# Altium schematic sheet Source_Pi.SchDoc(Source_Pi)
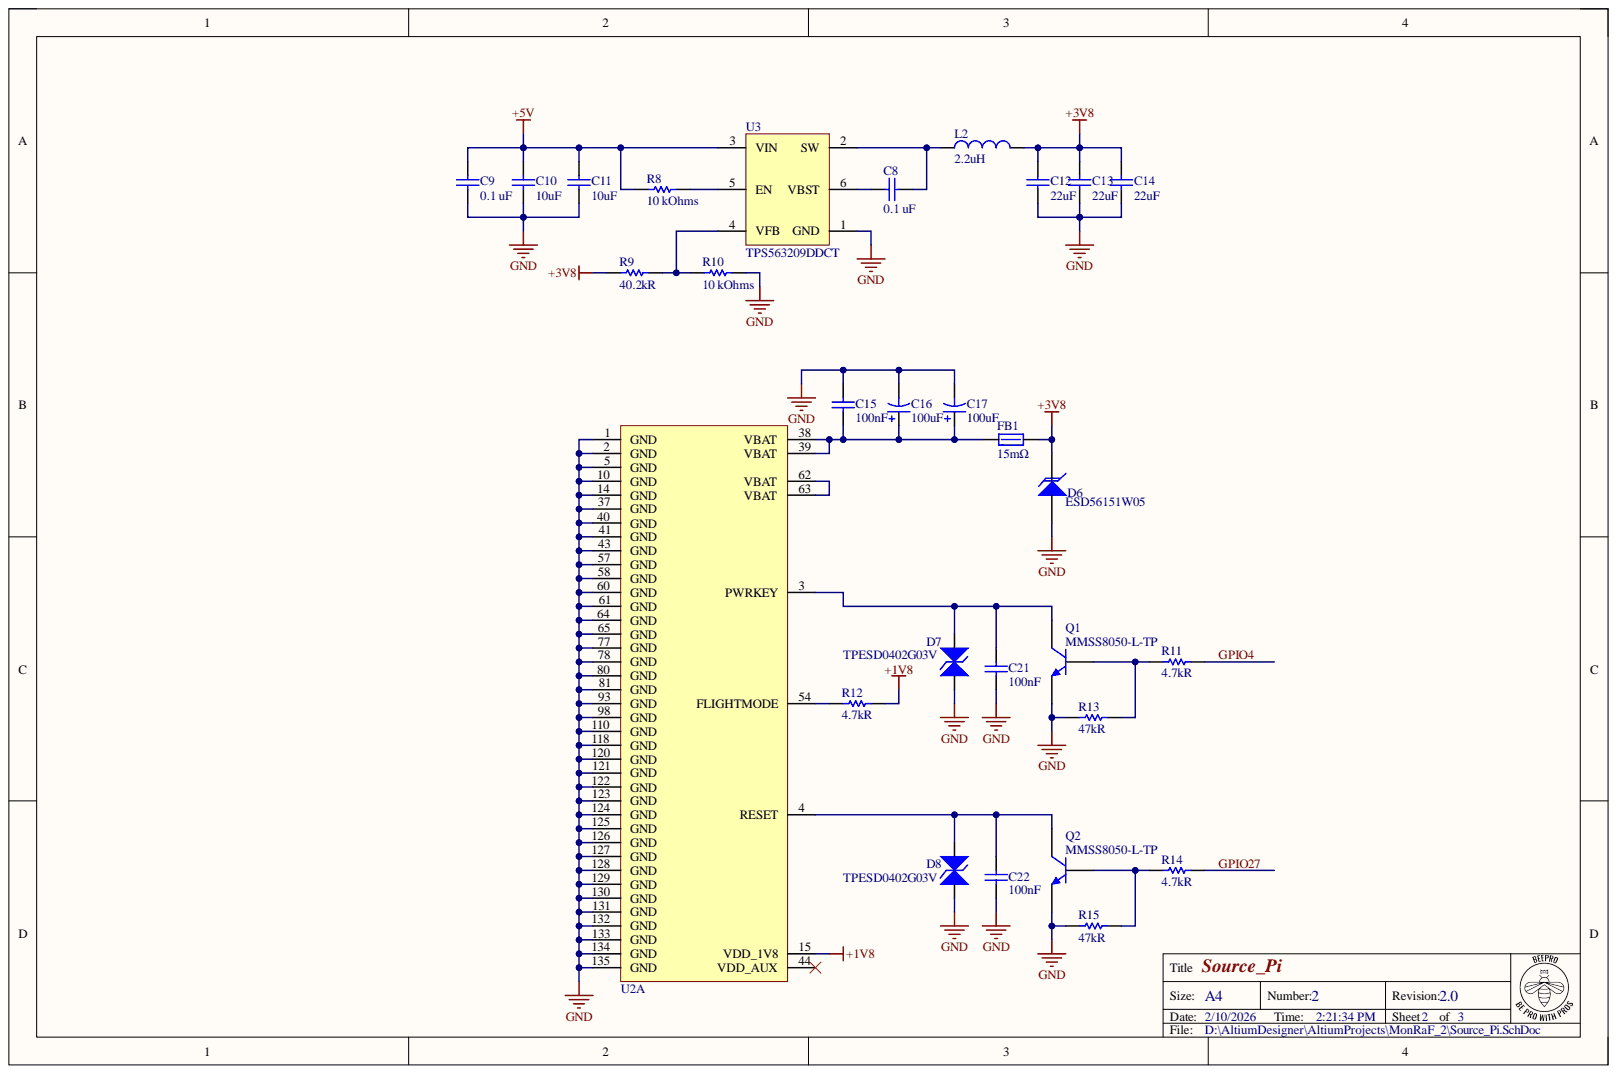
[diagram p0: Source_Pi.SchDoc]

## Parts
C8  Capacitance: 0.1 uF  [0603N]  (hidden comment: 0603YC104KAT2A)
C9  0603YC104KAT2A  [0603N]  (value not drawn)
C10  Capacitance: 10uF  [0603N]  (hidden comment: C1608X5R1E106M080AC)
C11  Capacitance: 10uF  [0603N]  (hidden comment: C1608X5R1E106M080AC)
C12  Capacitance: 22uF  [0603N]  (hidden comment: C1608JB1A226M080AC)
C13  Capacitance: 22uF  [0603N]  (hidden comment: C1608JB1A226M080AC)
C14  Capacitance: 22uF  [0603N]  (hidden comment: C1608JB1A226M080AC)
C15  Capacitance: 100nF  [0402N]  (hidden comment: 04026D104KAT2A)
C16  value 100uF  [Case-A]  (hidden comment: TMCMA0J107MTRF)
C17  value 100uF  [Case-A]  (hidden comment: TMCMA0J107MTRF)
C21  Capacitance: 100nF  [0402N]  (hidden comment: 04026D104KAT2A)
C22  Capacitance: 100nF  [0402N]  (hidden comment: 04026D104KAT2A)
D6  ESD56151W05  [SOD-323]
D7  TPESD0402G03V  [DFN0402-2N]
D8  TPESD0402G03V  [DFN0402-2N]
FB1  value 15mΩ  [0805]  (hidden comment: BLM21PG300SN1D)
L2  Inductance-Nom (L): 2.2uH  [SRN6045]  (hidden comment: SRN6045TA-2R2Y)
Q1  MMSS8050-L-TP  [SOT23-3N]
Q2  MMSS8050-L-TP  [SOT23-3N]
R8  Resistance: 10 kOhms  [0603N]  (hidden comment: CRCW060310K0FKEA)
R9  value 40.2kR  [0603N]  (hidden comment: C0603FR-0740K2L)
R10  Resistance: 10 kOhms  [0603N]  (hidden comment: CRCW060310K0FKEA)
R11  Resistance: 4.7kR  [0402N]  (hidden comment: RC0402FR-074K7L)
R12  Resistance: 4.7kR  [0402N]  (hidden comment: RC0402FR-074K7L)
R13  Resistance: 47kR  [0402N]  (hidden comment: AC0402FR-0747KL)
R14  Resistance: 4.7kR  [0402N]  (hidden comment: RC0402FR-074K7L)
R15  Resistance: 47kR  [0402N]  (hidden comment: AC0402FR-0747KL)
U2  SIM7600G-H  [LCC+LGA-119N]  (value not drawn)  (part A)
U3  TPS563209  Manufacturer Part Number: TPS563209DDCT  [SOT23-6N]

## Nets
+1V8: R12.2 U2.15(VDD_1V8)
+3V8: C12.2 C13.2 C14.2 D6.1 FB1.2 L2.2 R9.1
+5V: C9.2 C10.2 C11.2 R8.2 U3.3(VIN)
GND: C9.1 C10.1 C11.1 C12.1 C13.1 C14.1 C15.1 C16.2 C17.2 C21.2 C22.2 D6.2 D7.1 D8.1 Q1.2 Q2.2 R10.1 R13.1 R15.1 U2.1(GND) U2.2(GND) U2.5(GND) U2.10(GND) U2.14(GND) U2.37(GND) U2.40(GND) U2.41(GND) U2.43(GND) U2.57(GND) U2.58(GND) U2.60(GND) U2.61(GND) U2.64(GND) U2.65(GND) U2.77(GND) U2.78(GND) U2.80(GND) U2.81(GND) U2.93(GND) U2.98(GND) U2.110(GND) U2.118(GND) U2.120(GND) U2.121(GND) U2.122(GND) U2.123(GND) U2.124(GND) U2.125(GND) U2.126(GND) U2.127(GND) U2.128(GND) U2.129(GND) U2.130(GND) U2.131(GND) U2.132(GND) U2.133(GND) U2.134(GND) U2.135(GND) U3.1(GND)
GPIO4: R11.2
GPIO27: R14.2
NetC8_1: C8.1 L2.1 U3.2(SW)
NetC8_2: C8.2 U3.6(VBST)
NetC15_2: C15.2 C16.1 C17.1 FB1.1 U2.38(VBAT) U2.39(VBAT)
NetC21_1: C21.1 D7.2 Q1.3 U2.3(PWRKEY)
NetC22_1: C22.1 D8.2 Q2.3 U2.4(RESET)
NetQ1_1: Q1.1 R11.1 R13.2
NetQ2_1: Q2.1 R14.1 R15.2
NetR8_1: R8.1 U3.5(EN)
NetR9_2: R9.2 R10.2 U3.4(VFB)
NetR12_1: R12.1 U2.54(FLIGHTMODE)
NetU2_62: U2.62(VBAT) U2.63(VBAT)

Not connected: U2 44(VDD_AUX)

## Library data of the parts
C8 = 0603YC104KAT2A: Multilayer Ceramic Capacitors MLCC - SMD/SMT 16V .1uF X7R 0603 10% Tol; Brand: AVX; Capacitance - nF: 100 nF; Capacitance - pF: 100000 pF; Class: Class 2; Type: X7R MLC Capacitors; Voltage Rating DC: 16 VDC; Case Code - in: 0603; Case Code - mm: 1608; Category: Multilayer Ceramic Capacitors MLCC - SMD/SMT; Component Kind: Standard; ComponentLink1Description: http://www.mouser.com/ds/2/40/X7RDielectric-777024.pdf; ComponentLink1URL: http://www.mouser.com/ds/2/40/X7RDielectric-777024.pdf; Dielectric: X7R; Height: 0.35 mm; Length: 1.6 mm; Library Name: Capacitor_Ceramic.IntLib; Manufacturer: AVX; Manufacturer Part Number 1: 0603YC104KAT2A; Maximum Operating Temperature: + 125 C; Minimum Operating Temperature: - 55 C; Minimum Order Quantity: 1; Package / Case: 0603 (1608 metric); Packaging: Reel; Pricing 1: 1=0.100, 50=0.011, 100=0.007, 500=0.006, 1000=0.005, 4000=0.003 (USD); Product: General Type MLCCs; Reeling: True; RoHS: RoHS Compliant By Exemption; RoHS - Mouser: E; Standard Pack Qty: 4000; Stock 1: 207167; Supplier 1: Mouser; Supplier Part Number 1: 581-060316C104K; Termination: -; Termination Style: SMD/SMT; Tolerance: 10 %; Width: 0.8 mm
C9 = 0603YC104KAT2A: Multilayer Ceramic Capacitors MLCC - SMD/SMT 16V .1uF X7R 0603 10% Tol; Brand: AVX; Capacitance: 0.1 uF; Capacitance - nF: 100 nF; Capacitance - pF: 100000 pF; Class: Class 2; Type: X7R MLC Capacitors; Voltage Rating DC: 16 VDC; Case Code - in: 0603; Case Code - mm: 1608; Category: Multilayer Ceramic Capacitors MLCC - SMD/SMT; Component Kind: Standard; ComponentLink1Description: http://www.mouser.com/ds/2/40/X7RDielectric-777024.pdf; ComponentLink1URL: http://www.mouser.com/ds/2/40/X7RDielectric-777024.pdf; Dielectric: X7R; Height: 0.35 mm; Length: 1.6 mm; Library Name: Capacitor_Ceramic.IntLib; Manufacturer: AVX; Manufacturer Part Number 1: 0603YC104KAT2A; Maximum Operating Temperature: + 125 C; Minimum Operating Temperature: - 55 C; Minimum Order Quantity: 1; Package / Case: 0603 (1608 metric); Packaging: Reel; Pricing 1: 1=0.100, 50=0.011, 100=0.007, 500=0.006, 1000=0.005, 4000=0.003 (USD); Product: General Type MLCCs; Reeling: True; RoHS: RoHS Compliant By Exemption; RoHS - Mouser: E; Standard Pack Qty: 4000; Stock 1: 207167; Supplier 1: Mouser; Supplier Part Number 1: 581-060316C104K; Termination: -; Termination Style: SMD/SMT; Tolerance: 10 %; Width: 0.8 mm
C10 C11 = C1608X5R1E106M080AC: CAP CER 10UF 25V X5R 0603; Manufacturer: TDK; Dielectric Material: Ceramic; Tolerance: 20%; Case Code (Imperial): 0603; Case Code (Metric): 1608; Case/Package: 0603; Component Kind: Standard; ComponentLink1Description: https://product.tdk.com/info/en/catalog/datasheets/mlcc_commercial_general_en.pdf; ComponentLink1URL: https://product.tdk.com/info/en/catalog/datasheets/mlcc_commercial_general_en.pdf; ComponentLink2Description: http://www.farnell.com/datasheets/2291921.pdf; ComponentLink2URL: http://www.farnell.com/datasheets/2291921.pdf; ComponentLink3Description: http://www.farnell.com/datasheets/1913358.pdf; ComponentLink3URL: http://www.farnell.com/datasheets/1913358.pdf; ComponentLink4Description: http://components.arrow.com/part/dataview/57436044S459551N1165; ComponentLink4URL: http://components.arrow.com/part/dataview/57436044S459551N1165; ComponentLink5Description: http://components.arrow.com/part/dataview/0323_06887183; ComponentLink5URL: http://components.arrow.com/part/dataview/0323_06887183; Composition: Ceramic; Depth: 0.8mm; Dielectric: X5R; Height: 0.8mm; Lead Free: Lead Free; Length: 1.6mm; Library Name: Capacitor_Ceramic.IntLib; Manufacturer Part Number: C1608X5R1E106M080AC; Max Operating Temperature: 85°C; Min Operating Temperature: -55°C; Mount: Surface Mount; Package Quantity: 1; Packaging: Tape and Reel; Pricing 1: 1=0.88, 10=0.742, 50=0.742, 100=0.364, 1000=0.301, 10000=0.28 (USD); REACH SVHC: No SVHC; Stock 1: 225396; Supplier 1: Mouser; Supplier Part Number 1: 810-C1608X5R1E106M; Termination: SMD/SMT; Thickness: 0.039inch; Voltage Rating: 25V; Voltage Rating (DC): 25V; Weight: 7.1E-05oz; Width: 0.8mm
C12-C14 = C1608JB1A226M080AC: CAP CER 22UF 10V JB 0603; Manufacturer: TDK; Dielectric Material: Ceramic; Tolerance: 20%; Case Code (Imperial): 0603; Case Code (Metric): 1608; Case/Package: 0603; Category: Ceramic Capacitors; Component Kind: Standard; ComponentLink1Description: https://datasheet.ciiva.com/14905/tdkmlcccaprange-14905338.pdf?src-supplier=Digi-Key; ComponentLink1URL: https://datasheet.ciiva.com/14905/tdkmlcccaprange-14905338.pdf?src-supplier=Digi-Key; ComponentLink2Description: https://datasheet.ciiva.com/14905/tdkmlcccaprange-14905341.pdf?src-supplier=Digi-Key; ComponentLink2URL: https://datasheet.ciiva.com/14905/tdkmlcccaprange-14905341.pdf?src-supplier=Digi-Key; ComponentLink3Description: https://datasheet.ciiva.com/14905/tdkmlcccaprange-14905339.pdf?src-supplier=Digi-Key; ComponentLink3URL: https://datasheet.ciiva.com/14905/tdkmlcccaprange-14905339.pdf?src-supplier=Digi-Key; Lead Free: Lead Free; Length: 0.063inch; Library Name: Capacitor_Ceramic.IntLib; Manufacturer Part Number: C1608JB1A226M080AC; Max Operating Temperature: 85°C; Min Operating Temperature: -25°C; Mount: Surface Mount; Package Quantity: 4000; Packaging: Tape and Reel; Pricing 1: 1=0.45000, 10=0.23900, 50=0.23900, 100=0.19500, 1000=0.14700, 10000=0.14000 (USD); RoHS: Yes; RoHS Compliant: Yes; Series: C; Stock 1: 20532; Supplier 1: Mouser; Supplier Part Number 1: 810-C1608JB1A226M8AC; Thickness: 0.035inch; Voltage Rating: 10V; Voltage Rating (DC): 10V; Width: 0.031inch
C15 C21 C22 = 04026D104KAT2A: AVX   04026D104KAT2A   Multilayer Ceramic Capacitor, 0.1 F,  10%, X5R, 6.3 V, 0402 [1005 Metric]; Manufacturer: Kyocera AVX; Voltage: 6.3V; Case Code (Imperial): 0402; Case Code (Metric): 1005; Case/Package: 0402; Component Kind: Standard; ComponentLink1Description: https://datasheet.ciiva.com/11787/0900766b80def1a5-11787108.pdf?src-supplier=RSComponents; ComponentLink1URL: https://datasheet.ciiva.com/11787/0900766b80def1a5-11787108.pdf?src-supplier=RSComponents; ComponentLink2Description: https://datasheet.ciiva.com/34303/2009885-34303800.pdf?src-supplier=Jameco; ComponentLink2URL: https://datasheet.ciiva.com/34303/2009885-34303800.pdf?src-supplier=Jameco; ComponentLink3Description: https://datasheet.ciiva.com/1123/1666675-1123895.pdf?src-supplier=Element14; ComponentLink3URL: https://datasheet.ciiva.com/1123/1666675-1123895.pdf?src-supplier=Element14; ComponentLink4Description: https://datasheet.ciiva.com/7709/1666675-7709559.pdf?src-supplier=Element14; ComponentLink4URL: https://datasheet.ciiva.com/7709/1666675-7709559.pdf?src-supplier=Element14; ComponentLink5Description: https://datasheet.ciiva.com/17488/cx5r-17488599.pdf?src-supplier=Avnet+Europe; ComponentLink5URL: https://datasheet.ciiva.com/17488/cx5r-17488599.pdf?src-supplier=Avnet+Europe; ComponentLink6Description: https://datasheet.ciiva.com/1123/1666675-1123896.pdf?src-supplier=Element14; ComponentLink6URL: https://datasheet.ciiva.com/1123/1666675-1123896.pdf?src-supplier=Element14; ComponentLink7Description: https://datasheet.ciiva.com/11499/cx5r-11499578.pdf?src-supplier=Avnet; ComponentLink7URL: https://datasheet.ciiva.com/11499/cx5r-11499578.pdf?src-supplier=Avnet; ComponentLink8Description: https://datasheet.ciiva.com/210/cx5r-210347.pdf?src-supplier=Digi-Key; ComponentLink8URL: https://datasheet.ciiva.com/210/cx5r-210347.pdf?src-supplier=Digi-Key; ComponentLink9Description: https://datasheet.ciiva.com/14886/cx5r-14886812.pdf?src-supplier=Digi-Key; ComponentLink9URL: https://datasheet.ciiva.com/14886/cx5r-14886812.pdf?src-supplier=Digi-Key; ComponentLink10Description: https://datasheet.ciiva.com/2987/cx5r-2987693.pdf?src-supplier=Avnet; ComponentLink10URL: https://datasheet.ciiva.com/2987/cx5r-2987693.pdf?src-supplier=Avnet; Contact Plating: Tin; Depth: 0.5mm; Dielectric: X5R; Dielectric Material: Ceramic; Height: 0.56mm; Lead Free: Lead Free; Length: 1mm; Library Name: Capacitor_Ceramic.IntLib; Manufacturer Part Number: 04026D104KAT2A; Max Operating Temperature: 85°C; Min Operating Temperature: -55°C; Mount: Surface Mount; Package Quantity: 1; Packaging: Tape and Reel; Pricing 1: 1 = 0.10000, 10 = 0.04100, 50 = 0.04100, 100 = 0.01900, 1000 = 0.01000, 10000 = 0.00700 (USD); Radiation Hardening: No; REACH SVHC: No SVHC; RoHS: Yes; Stock 1: 118561; Supplier 1: Mouser; Supplier Part Number 1: 581-04026D104KAT2A; Termination: SMD/SMT; Thickness: 0.022inch; Tolerance: 10%; Voltage Rating: 6.3V; Voltage Rating (DC): 6.3V; Width: 0.02inch
C16 C17 = TMCMA0J107MTRF: Tantalum Capacitor, Polarized, Tantalum (dry/solid), 6.3V, 20% +Tol, 20% -Tol, 100uF, Surface Mount, 1306; Manufacturer: Vishay Sprague; ESR (Equivalent Series Resistance): 1.8R; Capacitor Type: Tantalum; Case/Package: 1206; Category: Tantalum Capacitors; Component Kind: Standard; ComponentLink1Description: https://datasheet.ciiva.com:443/pdfs/VipMasterIC/IC/VISH/VISH-S-A0003607254/VISH-S-A0003607254-1.pdf?src-supplier=IHS+Markit; ComponentLink1URL: https://datasheet.ciiva.com:443/pdfs/VipMasterIC/IC/VISH/VISH-S-A0003607254/VISH-S-A0003607254-1.pdf?src-supplier=IHS+Markit; ComponentLink2Description: http://www.farnell.com/datasheets/2245108.pdf?src-supplier=Farnell; ComponentLink2URL: http://www.farnell.com/datasheets/2245108.pdf?src-supplier=Farnell; ComponentLink3Description: http://www.mouser.com/ds/2/427/tmcm-515668.pdf?src-supplier=Mouser; ComponentLink3URL: http://www.mouser.com/ds/2/427/tmcm-515668.pdf?src-supplier=Mouser; ComponentLink4Description: http://datasheet.octopart.com/TMCMA0J107MTRF-Vishay-datasheet-46753192.pdf?src-supplier=Farnell; ComponentLink4URL: http://datasheet.octopart.com/TMCMA0J107MTRF-Vishay-datasheet-46753192.pdf?src-supplier=Farnell; ComponentLink5Description: http://datasheet.octopart.com/TMCMA0J107MTRF-Vishay-datasheet-46753192.pdf?src-supplier=Newark; ComponentLink5URL: http://datasheet.octopart.com/TMCMA0J107MTRF-Vishay-datasheet-46753192.pdf?src-supplier=Newark; ComponentLink6Description: https://datasheet.octopart.com/TMCMA0J107MTRF-Vishay-datasheet-78835373.pdf?src-supplier=Element14; ComponentLink6URL: https://datasheet.octopart.com/TMCMA0J107MTRF-Vishay-datasheet-78835373.pdf?src-supplier=Element14; ComponentLink7Description: http://datasheet.octopart.com/TMCMA0J107MTRF-Vishay-datasheet-46753192.pdf?src-supplier=Distrelec; ComponentLink7URL: http://datasheet.octopart.com/TMCMA0J107MTRF-Vishay-datasheet-46753192.pdf?src-supplier=Distrelec; ComponentLink8Description: http://www.vishay.com/docs/40178/tmcm.pdf?src-supplier=Avnet; ComponentLink8URL: http://www.vishay.com/docs/40178/tmcm.pdf?src-supplier=Avnet; ComponentLink9Description: http://datasheet.octopart.com/TMCMA0J107MTRF-Vishay-datasheet-46753192.pdf?src-supplier=Digikey; ComponentLink9URL: http://datasheet.octopart.com/TMCMA0J107MTRF-Vishay-datasheet-46753192.pdf?src-supplier=Digikey; ComponentLink10Description: http://datasheet.octopart.com/TMCMA0J107MTRF-Vishay-datasheet-46753192.pdf?src-supplier=Future+Electronics; ComponentLink10URL: http://datasheet.octopart.com/TMCMA0J107MTRF-Vishay-datasheet-46753192.pdf?src-supplier=Future+Electronics; ComponentLink11Description: http://datasheet.octopart.com/TMCMA0J107MTRF-Vishay-datasheet-46753192.pdf?src-supplier=TTI; ComponentLink11URL: http://datasheet.octopart.com/TMCMA0J107MTRF-Vishay-datasheet-46753192.pdf?src-supplier=TTI; Dielectric Material: Tantalum; Height: 1.6mm; JESD-609 Code: e3; Length: 3.2mm; Library Name: Tantalum_Capacitor.IntLib; Manufacturer Part Number: TMCMA0J107MTRF; Max Operating Temperature: 125°C; Min Operating Temperature: -55°C; Package Style: SMTMeter; Packaging: Tape and Reel; Pins: 2; Polarity: Polarized; Pricing 1: 5=0.1537, 50=0.1245, 150=0.1103, 500=0.0976, 2000=0.0789, 4000=0.0768 (USD); Rated DC Voltage (URdc): 6.3V; Reach SVHC Compliant: Yes; RoHS: Yes; RoHS Compliant: Yes; Stock 1: 20981; Supplier 1: LCSC; Supplier Part Number 1: C1954161; Terminal Finish: Tin; Tolerance  (fill in as +-): 20%; Tolerance (fill in as +-): 20%; Width: 1.6mm
D6 = ESD56151W05-2/TR: 145A@8/20us 7.5V 2.4kW@8/20us 6.3V Bidirectional 5V SOD-323 TVS Diodes ROHS; Component Kind: Standard; Library Name: Diodes.IntLib
D7 D8 = TPESD0402G03V: 0402 ESD and Surge Protection (TVS/ESD) ROHS; Manufacturer: Tech Public; Category: Uncategorized; Component Kind: Standard; Library Name: Diodes.IntLib; Manufacturer Part Number: TPESD0402G03V; Pricing: 20=0.158, 20=0.1448, 20=0.0198, 20=0.0173, 200=0.1258, 200=0.0172, 200=0.1373, 200=0.015, 600=0.1261, 600=0.1155, 600=0.0138, 600=0.0158, 2000=0.1097, 2000=0.0131, 2000=0.015, 2000=0.1197, 10000=0.1014, 10000=0.0111, 10000=0.0929, 10000=0.0127, 20000=0.0982, 20000=0.09, 20000=0.0107, 20000=0.0123 (HKD); Stock: 11840; Supplier: LCSC; Supplier Part Number: C558614
FB1 = BLM21PG300SN1D: Ferrite Beads Multi-Layer 30Ohm 100MHz 4A 14mOhm DCR 0805 Paper T/R; Manufacturer: Murata; Current Rating: 2.3A; DC Current: 3A; DC Resistance (DCR): 14mΩ; Impedance: 30Ω; Material: Ferrite, Steel; Number of Circuits: 1; Shielding: Unshielded; Tolerance: 25%; Case Code (Imperial): 0805; Case Code (Metric): 2012; Case/Package: 0805; Category: Ferrite Beads and Chips; Clock Frequency: 100MHz; Component Kind: Standard; ComponentLink1Description: https://datasheet.octopart.com:443/BLM21PG300SN1D-Murata-datasheet-12522583.pdf?src-supplier=Upverter; ComponentLink1URL: https://datasheet.octopart.com:443/BLM21PG300SN1D-Murata-datasheet-12522583.pdf?src-supplier=Upverter; ComponentLink2Description: https://datasheet.octopart.com:443/BLM21PG300SN1D-Murata-datasheet-10083551.pdf?src-supplier=Upverter; ComponentLink2URL: https://datasheet.octopart.com:443/BLM21PG300SN1D-Murata-datasheet-10083551.pdf?src-supplier=Upverter; ComponentLink3Description: https://datasheet.octopart.com:443/BLM21PG300SN1D-Murata-datasheet-8508678.pdf?src-supplier=Upverter; ComponentLink3URL: https://datasheet.octopart.com:443/BLM21PG300SN1D-Murata-datasheet-8508678.pdf?src-supplier=Upverter; ComponentLink4Description: https://datasheet.octopart.com:443/BLM21PG300SN1D-Murata-datasheet-166171482.pdf?src-supplier=IHS; ComponentLink4URL: https://datasheet.octopart.com:443/BLM21PG300SN1D-Murata-datasheet-166171482.pdf?src-supplier=IHS; ComponentLink5Description: https://datasheet.octopart.com:443/BLM21PG300SN1D-Murata-datasheet-11743916.pdf?src-supplier=Newark; ComponentLink5URL: https://datasheet.octopart.com:443/BLM21PG300SN1D-Murata-datasheet-11743916.pdf?src-supplier=Newark; ComponentLink6Description: https://datasheet.octopart.com:443/BLM21PG300SN1D-Murata-datasheet-53019.pdf?src-supplier=Newark; ComponentLink6URL: https://datasheet.octopart.com:443/BLM21PG300SN1D-Murata-datasheet-53019.pdf?src-supplier=Newark; ComponentLink7Description: https://datasheet.octopart.com:443/BLM21PG300SN1D-Murata-datasheet-8359900.pdf?src-supplier=_legacy+Avnet; ComponentLink7URL: https://datasheet.octopart.com:443/BLM21PG300SN1D-Murata-datasheet-8359900.pdf?src-supplier=_legacy+Avnet; ComponentLink8Description: https://datasheet.octopart.com:443/BLM21PG300SN1D-Murata-datasheet-554271.pdf?src-supplier=_legacy+Avnet; ComponentLink8URL: https://datasheet.octopart.com:443/BLM21PG300SN1D-Murata-datasheet-554271.pdf?src-supplier=_legacy+Avnet; ComponentLink9Description: https://datasheet.octopart.com:443/BLM21PG300SN1D-Murata-datasheet-141258122.pdf?src-supplier=Ciiva; ComponentLink9URL: https://datasheet.octopart.com:443/BLM21PG300SN1D-Murata-datasheet-141258122.pdf?src-supplier=Ciiva; ComponentLink10Description: https://datasheet.octopart.com:443/BLM21PG300SN1D-Murata-datasheet-138707422.pdf?src-supplier=Ciiva; ComponentLink10URL: https://datasheet.octopart.com:443/BLM21PG300SN1D-Murata-datasheet-138707422.pdf?src-supplier=Ciiva; ComponentLink11Description: https://datasheet.octopart.com:443/BLM21PG300SN1D-Murata-datasheet-135086728.pdf?src-supplier=TME; ComponentLink11URL: https://datasheet.octopart.com:443/BLM21PG300SN1D-Murata-datasheet-135086728.pdf?src-supplier=TME; ComponentLink12Description: https://datasheet.octopart.com:443/BLM21PG300SN1D-Murata-datasheet-62086383.pdf?src-supplier=Future+Electronics; ComponentLink12URL: https://datasheet.octopart.com:443/BLM21PG300SN1D-Murata-datasheet-62086383.pdf?src-supplier=Future+Electronics; ComponentLink13Description: https://datasheet.octopart.com/BLM21PG300SN1D-Murata-datasheet-37129980.pdf; ComponentLink13URL: https://datasheet.octopart.com/BLM21PG300SN1D-Murata-datasheet-37129980.pdf; ComponentLink14Description: https://datasheet.octopart.com:443/BLM21PG300SN1D-Murata-datasheet-7546143.pdf?src-supplier=Jameco; ComponentLink14URL: https://datasheet.octopart.com:443/BLM21PG300SN1D-Murata-datasheet-7546143.pdf?src-supplier=Jameco; ComponentLink15Description: https://datasheet.octopart.com:443/BLM21PG300SN1D-Murata-datasheet-153769.pdf?src-supplier=Mouser; ComponentLink15URL: https://datasheet.octopart.com:443/BLM21PG300SN1D-Murata-datasheet-153769.pdf?src-supplier=Mouser; ComponentLink16Description: https://datasheet.octopart.com:443/BLM21PG300SN1D-Murata-datasheet-1584.pdf?src-supplier=RS+(Formerly+Allied+Electronics); ComponentLink16URL: https://datasheet.octopart.com:443/BLM21PG300SN1D-Murata-datasheet-1584.pdf?src-supplier=RS+(Formerly+Allied+Electronics); ComponentLink17Description: https://datasheet.octopart.com:443/BLM21PG300SN1D-Murata-datasheet-151955976.pdf?src-supplier=SOS+electronic; ComponentLink17URL: https://datasheet.octopart.com:443/BLM21PG300SN1D-Murata-datasheet-151955976.pdf?src-supplier=SOS+electronic; ComponentLink18Description: http://sigma.octopart.com:80/reach_statement/Murata-company-16.pdf?src-supplier=Compliance+Statements; ComponentLink18URL: http://sigma.octopart.com:80/reach_statement/Murata-company-16.pdf?src-supplier=Compliance+Statements; Core Material: Ferrite; Depth: 1.25mm; ESR: 14mΩ; Frequency: 100MHz; Height: 850µm; Lead Free: Lead Free; Length: 2mm; Library Name: Inductors.IntLib; Lifecycle Status: Production; Manufacturer Part Number: BLM21PG300SN1D; Max Current Rating: 4A; Max DC Current: 3A; Max Operating Temperature: 125°C; Military Standard: Not; Min Operating Temperature: -55°C; Number of Lines: 1; Number of Terminals: 2; Packaging: Tape and Reel; Pins: 2; Pricing: 50=0.0142, 50=0.0163, 50=0.1301, 50=0.1192, 500=0.013, 500=0.1038, 500=0.0114, 500=0.0951, 1500=0.0097, 1500=0.0111, 1500=0.0812, 1500=0.0886, 4000=0.010, 4000=0.0731, 4000=0.0798, 4000=0.0087, 24000=0.0079, 24000=0.0658, 24000=0.009, 24000=0.0719, 48000=0.0074, 48000=0.0085, 48000=0.0622, 48000=0.0679 (EUR); Radiation Hardening: No; REACH SVHC: Yes; RMS Current (Irms): 4A; RoHS: Compliant; Schedule B: 8504500000, 8504500000|8504500000|8504500000|8504500000|8504500000|8504500000|8504500000|8504500000|8504500000|8504500000|8504500000|8504500000|8504500000|8504500000|8504500000|8504500000, 8504500000|8504500000|8504500000|8504500000|8504500000|8504500000|8504500000|8504500000|8504500000|8504500000|8504500000|8504500000|8504500000|8504500000|8504500000|8504500000|8504500000, 8504500000|8504500000|8504500000|8504500000|8504500000|8504500000|8504500000|8504500000|8504500000|8504500000|8504500000|8504500000|8504500000|8504500000|8504500000|8504500000|8504500000|8504500000; Stock: 146550; Supplier: LCSC; Supplier Part Number: C16903; Termination: SMD/SMT; Test Frequency: 100MHz; Width: 1.25mm
L2 = SRN6045TA-2R2Y: General Purpose Inductor, 2.2uH, 30%, 1 Element, Ferrite-Core, SMD, 2424; Manufacturer: Bourns; Channels: 1; DC Resistance (DCR): 15mR; Inductor Application: POWER INDUCTOR; Max Current Rating: 6A; Quality Factor-Min (at L-nom): 15; Reference Standard: AEC-Q200; Self Resonant Frequency: 55MHz; Shape/Size Description: RECTANGULAR PACKAGE; Shielding: Shielded; Test Frequency: 1MHz; Category: Fixed Inductors; Component Kind: Standard; ComponentLink1Description: https://datasheet.ciiva.com:443/pdfs/VipMasterIC/IC/BOUR/BOUR-S-A0008509695/BOUR-S-A0008509695-1.pdf?src-supplier=IHS+Markit; ComponentLink1URL: https://datasheet.ciiva.com:443/pdfs/VipMasterIC/IC/BOUR/BOUR-S-A0008509695/BOUR-S-A0008509695-1.pdf?src-supplier=IHS+Markit; ComponentLink2Description: https://datasheet.octopart.com/SRN6045TA-2R2Y-Bourns-datasheet-68554779.pdf?src-supplier=Element14; ComponentLink2URL: https://datasheet.octopart.com/SRN6045TA-2R2Y-Bourns-datasheet-68554779.pdf?src-supplier=Element14; ComponentLink3Description: https://datasheet.octopart.com/SRN6045TA-2R2Y-Bourns-datasheet-66075751.pdf?src-supplier=Newark; ComponentLink3URL: https://datasheet.octopart.com/SRN6045TA-2R2Y-Bourns-datasheet-66075751.pdf?src-supplier=Newark; ComponentLink4Description: https://www.bourns.com/docs/Product-Datasheets/SRN6045TA.pdf?src-supplier=Digikey; ComponentLink4URL: https://www.bourns.com/docs/Product-Datasheets/SRN6045TA.pdf?src-supplier=Digikey; Core Material: Ferrite; Inductor Type: GENERAL PURPOSE INDUCTOR; JESD-609 Code: e3; Library Name: Inductors.IntLib; Manufacturer Part Number: SRN6045TA-2R2Y; Max Operating Temperature: 125°C; Min Operating Temperature: -55°C; Pins: 2; Pricing 1: 5=0.2498, 50=0.2044, 150=0.1849, 1000=0.1606, 2000=0.1498, 5000=0.1433 (USD); Reach SVHC Compliant: Yes; RoHS: Yes; RoHS Compliant: Yes; Stock 1: 770; Supplier 1: LCSC; Supplier Part Number 1: C1332316; Surface Mount: Yes; Terminal Finish: Tin; Terminal Placement: Dual Ended; Termination: One Surface; Tolerance: 30%
Q1 Q2 = MMSS8050-L-TP: TRANS NPN 25V 1.5A SOT-23; Manufacturer: MCC; Collector Base Voltage (VCBO): 40V; Collector Emitter Saturation Voltage: 500mV; Element Configuration: Single; Emitter Base Voltage (VEBO): 5V; Gain Bandwidth Product: 100MHz; hFE Min: 40; Max Power Dissipation: 625mW; Case/Package: TO-236-3; Collector Emitter Breakdown Voltage: 25V; Collector Emitter Voltage (VCEO): 500mV; Component Kind: Standard; ComponentLink1Description: https://datasheet.ciiva.com/6362/mmss8050sot-23-6362361.pdf; ComponentLink1URL: https://datasheet.ciiva.com/6362/mmss8050sot-23-6362361.pdf; ComponentLink2Description: https://datasheet.ciiva.com/16285/mmss8050sot-23-276387-16285577.pdf; ComponentLink2URL: https://datasheet.ciiva.com/16285/mmss8050sot-23-276387-16285577.pdf; ComponentLink3Description: https://datasheet.ciiva.com/6362/mmss8050sot-23-6362362.pdf; ComponentLink3URL: https://datasheet.ciiva.com/6362/mmss8050sot-23-6362362.pdf; ComponentLink4Description: https://datasheet.ciiva.com/6362/mmss8050sot-23-6362363.pdf; ComponentLink4URL: https://datasheet.ciiva.com/6362/mmss8050sot-23-6362363.pdf; Lead Free: Lead Free; Manufacturer Part Number: MMSS8050-L-TP; Max Breakdown Voltage: 25V; Max Collector Current: 1.5A; Max Operating Temperature: 150°C; Min Operating Temperature: -55°C; Mount: Surface Mount; Package Quantity: 3000; Packaging: Tape and Reel; Polarity: NPN; Pricing 1: 1=0.19, 10=0.165, 50=0.165, 100=0.058, 1000=0.04, 10000=0.026 (USD); Stock 1: 30112; Supplier 1: Mouser; Supplier Part Number 1: 833-MMSS8050-L-TP; Transition Frequency: 100MHz; Library Name: Micro Comercial Components.IntLib
R8 R10 = CRCW060310K0FKEA: Thick Film Resistors - SMD 1/10watt 10Kohms 1%; Manufacturer Part Number 1: CRCW060310K0FKTA; Brand: Vishay; Power Rating: 100 mW; Temperature Coefficient: 100 PPM / K; Voltage Rating: 75 V; Case Code - in: 0603; Case Code - mm: 1608; Category: Thick Film Resistors - SMD; Component Kind: Standard; ComponentLink1Description: http://www.mouser.com/ds/2/427/dcrcw-72725.pdf; ComponentLink1URL: http://www.mouser.com/ds/2/427/dcrcw-72725.pdf; Features: Metal glaze on high quality ceramic; Height: 0.45 mm; Length: 1.55 mm; Library Name: Resistor_0603.IntLib; Manufacturer: Vishay; Minimum Order Quantity: 1; Operating Temperature Range: - 55 C to + 155 C; Package / Case: 0603 (1608 metric); Packaging: Reel; Pricing 1: 1=0.120, 10=0.057, 100=0.025, 1000=0.018, 5000=0.013, 10000=0.011, 25000=0.010 (USD); Product: Thick Film Resistors SMD; Reeling: True; RoHS: No; RoHS - Mouser: N; Series: CRCW; Standard Pack Qty: 5000; Stock 1: 258284; Supplier 1: Mouser; Supplier Part Number 1: 71-CRCW0603-10K; Termination Style: SMD/SMT; Tolerance: 1 %; Width: 0.85 mm
R9 = C0603FR-0740K2L: Fixed Resistor, Metal Glaze/thick Film, 0.1W, 40200ohm, 75V, 1% +/-Tol, 100ppm/Cel, Surface Mount, 0603; Manufacturer: Yageo; Manufacturer Part Number: RC0603FR-0740K2L; Additional Feature: LASER TRIMMABLE; Package Height: 0.45mm; Package Length: 1.6mm; Package Width: 0.8mm; Power: 100mW; Rated Temperature: 70°C; Temperature Coefficient: 100ppm/°C; Case/Package: 0603; Category: Chip SMD Resistors; Component Kind: Standard; ComponentLink1Description: https://datasheet.ciiva.com:443/pdfs/VipMasterIC/IC/YAGO/YAGO-S-A0008145938/YAGO-S-A0008145938-1.pdf?src-supplier=IHS+Markit; ComponentLink1URL: https://datasheet.ciiva.com:443/pdfs/VipMasterIC/IC/YAGO/YAGO-S-A0008145938/YAGO-S-A0008145938-1.pdf?src-supplier=IHS+Markit; ComponentLink2Description: https://datasheet.ciiva.com/11809/0900766b80f96399-11809261.pdf?src-supplier=Rs; ComponentLink2URL: https://datasheet.ciiva.com/11809/0900766b80f96399-11809261.pdf?src-supplier=Rs; ComponentLink3Description: https://datasheet.ciiva.com/12555/rc0603-272842-12555385.pdf?src-supplier=Mouser; ComponentLink3URL: https://datasheet.ciiva.com/12555/rc0603-272842-12555385.pdf?src-supplier=Mouser; Construction: Chip; JESD-609 Code: e3; Library Name: Resistor_0603.IntLib; Max Operating Temperature: 155°C; Min Operating Temperature: -55°C; Mounting Technology: Surface Mount; Package Shape: Rectangular; Package Style: SMTMeter; Packaging: Tape and Reel; Pins: 2; Pricing 1: 1=0.1, 10=0.011, 50=0.011, 100=0.007, 1000=0.005, 10000=0.004 (USD); Reach SVHC Compliant: Yes; Resistor Type: FIXED RESISTOR; RoHS: Yes; RoHS Compliant: Yes; Stock 1: 131441; Supplier 1: Mouser; Supplier Part Number 1: 603-RC0603FR-0740K2L; Technology: METAL GLAZE/THICK FILM; Terminal Finish: Nickel; Termination: Wraparound; Tolerance: 1%; Working Voltage: 75V
R11 R12 R14 = RC0402FR-074K7L: YAGEO (PHYCOMP)         RC0402FR-074K7L             SMD Chip Resistor, Thick Film, 4.7 kohm, 50 V, 0402 [1005 Metric], 63 mW,  1%, RC Series; Power Rating: 62.5mW; Temperature Coefficient: 100ppm/°C; Voltage Rating: 50V; Case Code (Imperial): 0402; Case Code (Metric): 1005; Case/Package: 0402; Component Kind: Standard; ComponentLink1Description: https://datasheet.ciiva.com/11819/0900766b80c66297-11819507.pdf; ComponentLink1URL: https://datasheet.ciiva.com/11819/0900766b80c66297-11819507.pdf; ComponentLink2Description: https://datasheet.ciiva.com/7850/1018594-7850022.pdf; ComponentLink2URL: https://datasheet.ciiva.com/7850/1018594-7850022.pdf; ComponentLink3Description: https://datasheet.ciiva.com/7850/1018594-7850023.pdf; ComponentLink3URL: https://datasheet.ciiva.com/7850/1018594-7850023.pdf; ComponentLink4Description: https://datasheet.ciiva.com/15215/getdatasheetpartid-328119-15215035.pdf; ComponentLink4URL: https://datasheet.ciiva.com/15215/getdatasheetpartid-328119-15215035.pdf; ComponentLink5Description: https://datasheet.ciiva.com/22661/1686128-22661342.pdf; ComponentLink5URL: https://datasheet.ciiva.com/22661/1686128-22661342.pdf; Composition: Thick Film; Depth: 0.5mm; Height: 0.32mm; Lead Free: Lead Free; Length: 1mm; Library Name: Resistor_0402.IntLib; Manufacturer: Yageo; Manufacturer Part Number: RC0402FR-074K7L; Max Operating Temperature: 155°C; Military Standard: Not; Min Operating Temperature: -55°C; Number of Pins: 2; Packaging: Tape and Reel; Pricing 1: 1=0.1, 10=0.009, 50=0.009, 100=0.003, 1000=0.002, 10000=0.002 (USD); REACH SVHC: No SVHC; Stock 1: 1080519; Supplier 1: Mouser; Supplier Part Number 1: 603-RC0402FR-074K7L; Termination: SMD/SMT; Tolerance: 1%; Voltage Rating (DC): 50V; Width: 0.5mm
R13 R15 = AC0402FR-0747KL: Thick Film Resistors - SMD 1/16W 47K ohm 1% AEC-Q200; Manufacturer: Yageo; Max Power Dissipation: 63mW; Power Rating: 62.5mW; Temperature Coefficient: 100ppm/°C; Voltage Rating: 50V; Case Code (Imperial): 0402; Case Code (Metric): 1005; Case/Package: 0402; Component Kind: Standard; Composition: Thick Film; Features: Automotive AEC-Q200,Moisture Resistant; Height: 0.015inch; Lead Free: Lead Free; Length: 0.039inch; Library Name: Resistor_0402.IntLib; Manufacturer Part Number: AC0402FR-0747KL; Max Operating Temperature: 155°C; Min Operating Temperature: -55°C; Number of Terminations: 2; Packaging: Tape and Reel; Pricing 1: 1=0.1, 10=0.017, 50=0.017, 100=0.006, 1000=0.005, 10000=0.003 (USD); Stock 1: 5185; Supplier 1: Mouser; Supplier Part Number 1: 603-AC0402FR-0747KL; Termination: SMD/SMT; Tolerance: 1%; Weight: 2.3E-05oz; Width: 0.5mm
U2 = SIM7600G-H: Cellular Module, GSM, LTE-FDD, LTE-TDD, WCDMA, 3.4 V to 4.2 V, 30 mm x 30 mm x 2.9 mm; Manufacturer: Simcom; Manufacturer Part Number: SIM7600G-H R2; Category: RF Transceiver Modules and Modems; Component Kind: Standard; Length: 30m; Library Name: SIMCom.IntLib; Pricing: 1=51.98, 10=45.558, 25=43.3328, 100=40.2842 (USD); Stock: 421; Supplier: DigiKey; Supplier Part Number: 3781-SIM7600G-HR2-ND
U3 = TPS563209: Switching Regulator, 3A, 650kHz Switching Freq-Max, PDSO6; Manufacturer: Texas Instruments; Channels: 1; Control Technique: PULSE WIDTH MODULATION; Max Output current: 5.3A; Max Supply Voltage: 17V; Min Input Voltage: 4.5V; Nominal Supply Voltage: 12V; Package Body Material: Plastic; Switcher Configuration: BUCK; Case/Package: TSSOP; Category: Voltage Regulators - Switching; Component Kind: Standard; ComponentLink1Description: https://www.ti.com:443/lit/ds/symlink/tps562209.pdf?src-supplier=IHS+Markit; ComponentLink1URL: https://www.ti.com:443/lit/ds/symlink/tps562209.pdf?src-supplier=IHS+Markit; ComponentLink2Description: http://components.arrow.com/part/dataview/71881009S1084581N7713?src-supplier=Arrow+Electronics; ComponentLink2URL: http://components.arrow.com/part/dataview/71881009S1084581N7713?src-supplier=Arrow+Electronics; ComponentLink3Description: http://www.ti.com/general/docs/lit/getliterature.tsp?genericPartNumber=TPS562209&&fileType=pdf&src-supplier=Avnet; ComponentLink3URL: http://www.ti.com/general/docs/lit/getliterature.tsp?genericPartNumber=TPS562209&&fileType=pdf&src-supplier=Avnet; ComponentLink4Description: http://www.ti.com/general/docs/lit/getliterature.tsp?genericPartNumber=TPS563209&&fileType=pdf&src-supplier=Element14; ComponentLink4URL: http://www.ti.com/general/docs/lit/getliterature.tsp?genericPartNumber=TPS563209&&fileType=pdf&src-supplier=Element14; ComponentLink5Description: http://www.ti.com/lit/ds/symlink/tps563209.pdf?src-supplier=Digikey; ComponentLink5URL: http://www.ti.com/lit/ds/symlink/tps563209.pdf?src-supplier=Digikey; ComponentLink6Description: http://www.ti.com/general/docs/lit/getliterature.tsp?genericPartNumber=TPS563209&&fileType=pdf&src-supplier=Farnell; ComponentLink6URL: http://www.ti.com/general/docs/lit/getliterature.tsp?genericPartNumber=TPS563209&&fileType=pdf&src-supplier=Farnell; Frequency: 650kHz; Height - Seated (Max): 1.1mm; JESD-30 Code: R-PDSO-G6; JESD-609 Code: e3; Length: 2.9mm; Library Name: Power_Supply_IC.IntLib; Max Operating Temperature: 85°C; Min Operating Temperature: -40°C; Moisture Sensitivity Level: 1; Package Shape: Rectangular; Package Style: SMALL OUTLINE, THIN PROFILE, SHRINK PITCHMeter; Peak Reflow Temperature (Cel): 260°C; Pins: 6; Pricing 1: 1=1.9378, 10=1.6769, 30=1.5146, 250=1.3476, 500=1.2712, 1000=1.2394 (USD); Reach SVHC Compliant: Yes; RoHS: Yes; RoHS Compliant: Yes; Stock 1: 12; Supplier 1: LCSC; Supplier Part Number 1: C2070615; Surface Mount: Yes; Temperature Grade: INDUSTRIAL; Terminal Finish: Tin; Terminal Form: Gull Wing; Terminal Pitch: 0.95mm; Terminal Position: DUAL; Throw Configuration: SWITCHING REGULATOR; Width: 1.6mm
